# Altium schematic sheet power_supply.SchDoc
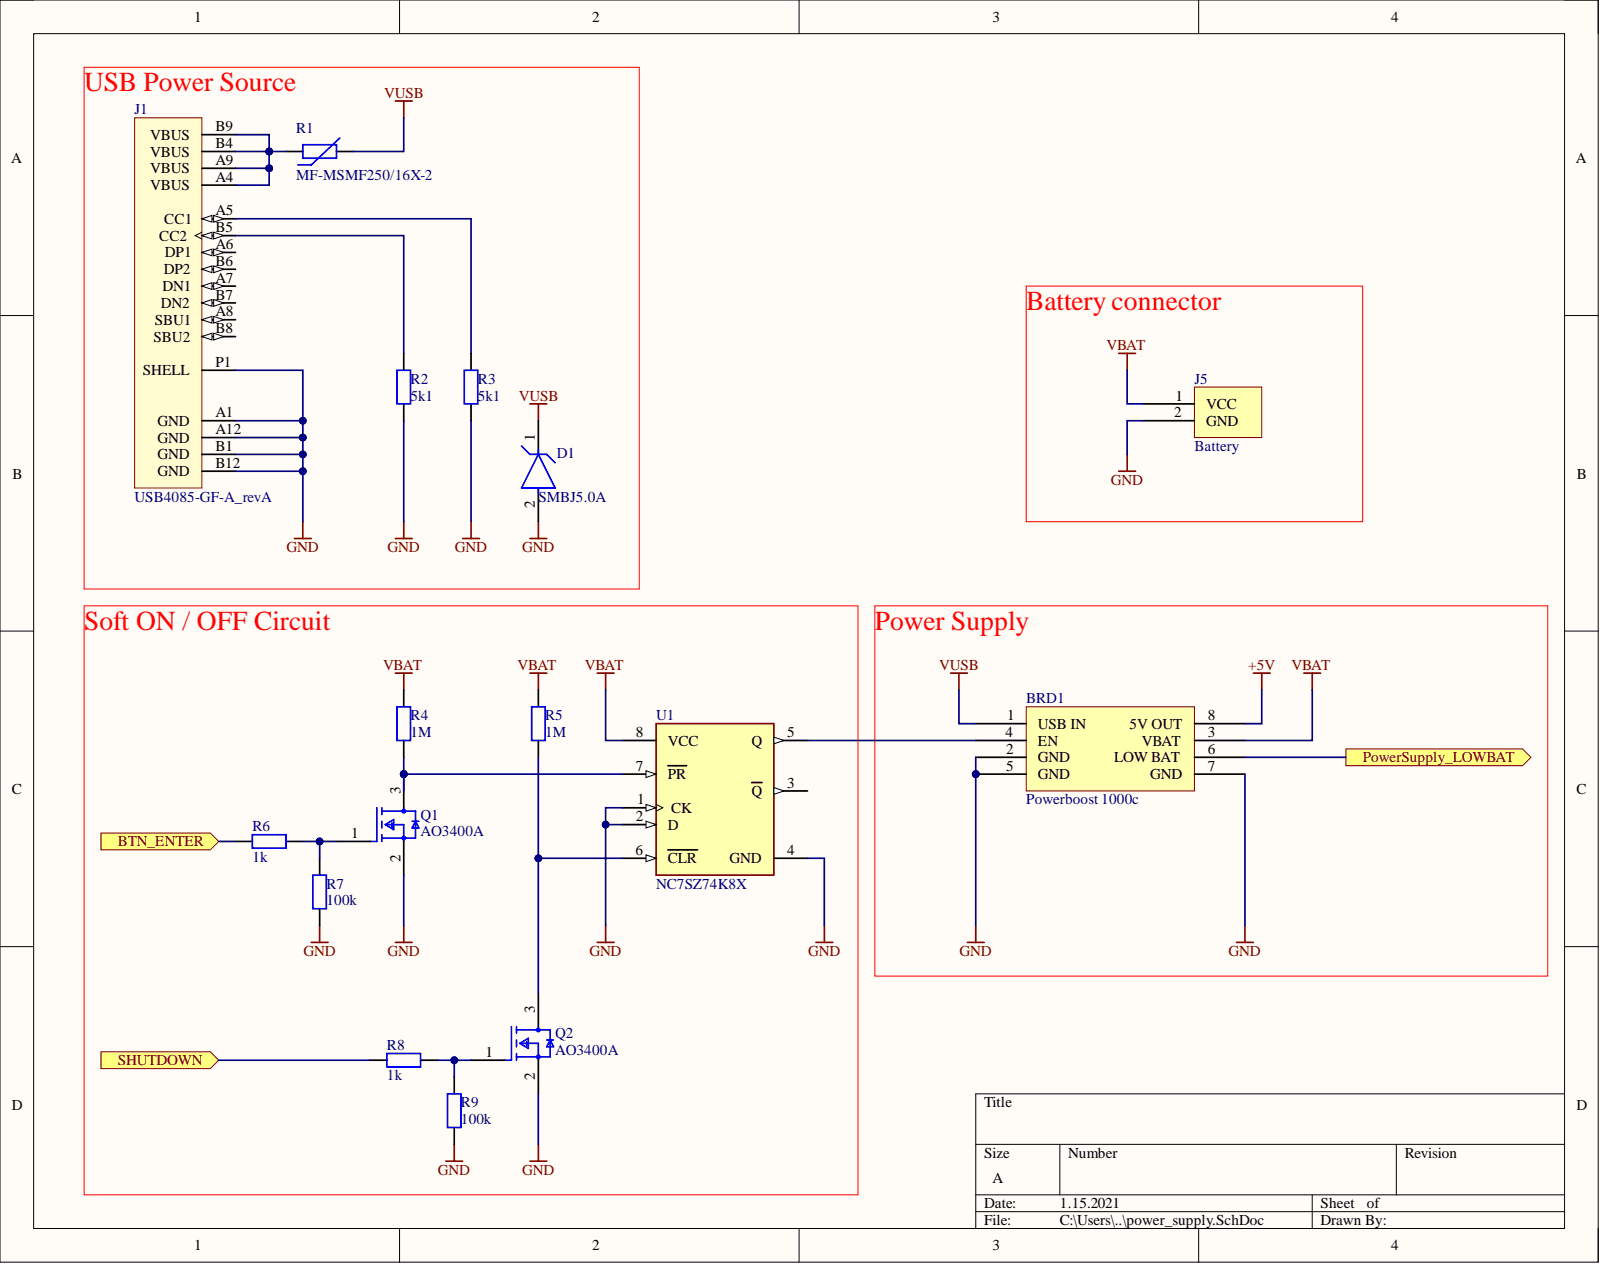
[diagram p0: power_supply.SchDoc]

## Parts
BRD1  Powerboost 1000c  [POWERBOOST_1000C]
D1  SMBJ5.0A  [FP-SMBJ-MFG]
J1  USB4085-GF-A_revA  [GCT_USB4085-GF-A_REVA]
J5  Battery  [FAN_CONNECTOR]
Q1  AO3400A  [SOT23]
Q2  AO3400A  [SOT23]
R1  MF-MSMF250/16X-2  [Res_1812]
R2  value 5k1  [RESC2013X50X30NL20T20]  (hidden comment: Res_0805)
R3  value 5k1  [RESC2013X50X30NL20T20]  (hidden comment: Res_0805)
R4  value 1M  [RESC2013X50X30NL20T20]  (hidden comment: Res_0805)
R5  value 1M  [RESC2013X50X30NL20T20]  (hidden comment: Res_0805)
R6  value 1k  [RESC2013X50X30NL20T20]  (hidden comment: Res_0805)
R7  value 100k  [RESC2013X50X30NL20T20]  (hidden comment: Res_0805)
R8  value 1k  [RESC2013X50X30NL20T20]  (hidden comment: Res_0805)
R9  value 100k  [RESC2013X50X30NL20T20]  (hidden comment: Res_0805)
U1  NC7SZ74K8X  [FP-846AN-MFG]

## Ports
BTN_ENTER, PowerSupply_LOWBAT, SHUTDOWN

## Nets
+5V: BRD1.8(5V_OUT)
GND: BRD1.2(GND) BRD1.5(GND) BRD1.7(GND) D1.2 J1.A1(GND) J1.A12(GND) J1.B1(GND) J1.B12(GND) J1.P1(SHELL) J5.2 Q1.2 Q2.2 R2.1 R3.1 R7.1 R9.1 U1.1(CK) U1.2(D) U1.4(GND)
VBAT: BRD1.3(VBAT) J5.1 R4.2 R5.2 U1.8(VCC)
VUSB: BRD1.1(USB_IN) D1.1 R1.2
BTN_ENTER: R6.1 ; port BTN_ENTER
PowerSupply_LOWBAT: BRD1.6(LOW_BAT) ; port PowerSupply_LOWBAT
SHUTDOWN: R8.1 ; port SHUTDOWN
NetBRD1_4: BRD1.4(EN) U1.5(Q)
NetJ1_A4: J1.A4(VBUS) J1.A9(VBUS) J1.B4(VBUS) J1.B9(VBUS) R1.1
NetJ1_A5: J1.A5(CC1) R3.2
NetJ1_B5: J1.B5(CC2) R2.2
NetQ1_1: Q1.1 R6.2 R7.2
NetQ1_3: Q1.3 R4.1 U1.7(PR)
NetQ2_1: Q2.1 R8.2 R9.2
NetQ2_3: Q2.3 R5.1 U1.6(CLR)

Not connected: J1 A6(DP1) A7(DN1) A8(SBU1) B6(DP2) B7(DN2) B8(SBU2); U1 3(Q)

## Library data of the parts
BRD1 = Powerboost_1000c: BOARD PWRBOOST1000 5V LIPO USB; Manufacturer: Adafruit Industries; Manufacturer Part Number: 2465; Evaluation Kit: Yes; Output Current: 1A; Output Voltage: 5.2V; Switching Frequency: 700kHz; Category: Embedded Processors and Controllers; Component Kind: Standard; ComponentLink1Description: https://media.digikey.com/pdf/Data%20Sheets/Adafruit%20PDFs/2465_Web.pdf?src-supplier=Digi-Key; ComponentLink1URL: https://media.digikey.com/pdf/Data%20Sheets/Adafruit%20PDFs/2465_Web.pdf?src-supplier=Digi-Key; Library Name: Schlib.SchLib; Package Quantity: 1; Pricing 1: 1=19.95000 (USD); RoHS: No; Stock 1: 834; Supplier 1: Digi-Key; Supplier Part Number 1: 1528-1349-ND
D1 = CMP-08607-000042-1: TVS DIODE 5V 9.2V DO214AA; Manufacturer: Littelfuse Inc; Breakdown Voltage: 6.4V; Direction: Unidirectional; Max Junction Temp: +150°C; Number Of Channels: 1; Peak Pulse Current: 65.3A; Peak Pulse Power: 600W; Automotive: No; Category: Diode; Clamping Voltage: 9.2V; Component Kind: Standard; ComponentLink2Description: Datasheet; ComponentLink2URL: https://www.littelfuse.com/~/media/electronics/datasheets/tvs_diodes/littelfuse_tvs_diode_smbj_datasheet.pdf.pdf; Device Class L1: Circuit Protection; Device Class L2: TVS Diodes; Device Class L3: unset; Digikey Description: TVS DIODE 5V 9.2V DO214AA; Height: 2.61mm; Lead Free: Yes; Library Name: Altium Content Vault; Manufacturer Part Number: SMBJ5.0A; Mouser Description: TVSS; Diode; For Data/Comm; Industrial Equip; 65.3A; SMD; Solder Term; Clamp-V 9.2V; Octopart: https://octopart.com/smbj5.0a-littelfuse-8835; Package: SMBJ; Reverse Standoff Voltage: 5V; RoHS: TRUE; Temperature: -65°C to +150°C; Temperature Range High: +150°C; Temperature Range Low: -65°C
J1 = USB-C port: USB 2.0 Type C Receptacle Dip Type, PCB Top Mount; MANUFACTURER: Global Connector Technology; Component Kind: Standard; PARTREV: A; STANDARD: Manufacturer Recommendations; Library Name: smart_lamp.SchLib
J5 = FAN: Tiger Claw(TM) Socket Strip, Through-hole, Vertical, -55 to 105 degC, 2 mm Pitch, 4-Pin, Female, RoHS; Manufacturer: Samtec; PartNumber: MMS-104-01-T-SV; Pitch: 2 mm; Component Kind: Standard; ComponentLink1Description: Manufacturer URL; ComponentLink1URL: http://www.samtec.com; ComponentLink2Description: Datasheet; ComponentLink2URL: http://www.samtec.com/documents/webfiles/pdf/MMS.PDF; ComponentLink3Description: Package Specification; ComponentLink3URL: http://www.samtec.com/documents/webfiles/cpdf/MMS-1XX-01-XXX-XX-XXX-MKT1.pdf; DatasheetVersion: F-214; Library Name: Schlib.SchLib; Mounting Technology: Through-hole; Orientation: Vertical; PackageDescription: 2mm Through Hole Box Connector Strip Assembly; PackageReference: MMS-104-01-X-SV; PackageVersion: AO, 2/1993; RoHS: True; Temperature Range: -55 to 105 degC
Q1 Q2 = MOSFET_AO3400A: MOSFET N-CH 30V 5.7A SOT23; Gate to Source Voltage (Vgs): 12V; Input Capacitance: 630pF; Max Power Dissipation: 1.4W; Number of Elements: 1; Rds On Max: 26.5mR; Case/Package: SOT-23; Component Kind: Standard; Continuous Drain Current (ID): 5.7A; Drain to Source Voltage (Vdss): 30V; Lead Free: Lead Free; Library Name: Schlib.SchLib; Max Operating Temperature: 150°C; Min Operating Temperature: -55°C; Mount: Surface Mount; Number of Pins: 3; Packaging: Tape and Reel; Power Dissipation: 1.4W; Radiation Hardening: No
R1 = PTC Fuse: PTC Resettable Fuse 2.5A(hold) 5A(trip) 16V 100A 1.2W 5s 0.015Ohm SMD Solder Pad 1812 T/R; Manufacturer: Bourns; Approvals: UL,CSA,TUV; Number of Terminals/Pins: 2; Resistance: 100mR; Case Code (Imperial): 1812; Case Code (Metric): 4532; Case/Package: 1812; Category: Fuses; Component Kind: Standard; ComponentLink1Description: https://datasheet.ciiva.com/3020/mfmsmf-3020420.pdf?src-supplier=Avnet; ComponentLink1URL: https://datasheet.ciiva.com/3020/mfmsmf-3020420.pdf?src-supplier=Avnet; ComponentLink2Description: https://datasheet.ciiva.com/16206/mfmsmf-16206071.pdf?src-supplier=Onlinecomponents.com; ComponentLink2URL: https://datasheet.ciiva.com/16206/mfmsmf-16206071.pdf?src-supplier=Onlinecomponents.com; ComponentLink3Description: https://datasheet.ciiva.com/7434/mfmsmf-7434296.pdf?src-supplier=Digi-Key; ComponentLink3URL: https://datasheet.ciiva.com/7434/mfmsmf-7434296.pdf?src-supplier=Digi-Key; ComponentLink4Description: https://datasheet.ciiva.com/32430/mf-msmf-series-eng-tds-32430600.pdf?src-supplier=Distrelec; ComponentLink4URL: https://datasheet.ciiva.com/32430/mf-msmf-series-eng-tds-32430600.pdf?src-supplier=Distrelec; ComponentLink5Description: https://datasheet.ciiva.com/7434/mfmsmf-7434298.pdf?src-supplier=Digi-Key; ComponentLink5URL: https://datasheet.ciiva.com/7434/mfmsmf-7434298.pdf?src-supplier=Digi-Key; ComponentLink6Description: https://datasheet.ciiva.com/16692/mfmsmf-16692202.pdf?src-supplier=Avnet+Europe; ComponentLink6URL: https://datasheet.ciiva.com/16692/mfmsmf-16692202.pdf?src-supplier=Avnet+Europe; ComponentLink7Description: https://datasheet.ciiva.com/30088/575mfmsmf-30088346.pdf?src-supplier=Onlinecomponents.com; ComponentLink7URL: https://datasheet.ciiva.com/30088/575mfmsmf-30088346.pdf?src-supplier=Onlinecomponents.com; ComponentLink8Description: https://datasheet.ciiva.com/7434/mfmsmf-7434299.pdf?src-supplier=Digi-Key; ComponentLink8URL: https://datasheet.ciiva.com/7434/mfmsmf-7434299.pdf?src-supplier=Digi-Key; ComponentLink9Description: https://datasheet.ciiva.com/14538/70153434-14538955.pdf?src-supplier=Allied+Electronics; ComponentLink9URL: https://datasheet.ciiva.com/14538/70153434-14538955.pdf?src-supplier=Allied+Electronics; ComponentLink10Description: https://datasheet.ciiva.com/8005/1786007-8005325.pdf?src-supplier=Element14; ComponentLink10URL: https://datasheet.ciiva.com/8005/1786007-8005325.pdf?src-supplier=Element14; ComponentLink11Description: https://datasheet.ciiva.com/48700/001055646-da-01-en-sich-ptc-reset-mf-msmf250-16x-2-2-5a16v-48700197.pdf?src-supplier=Conrad; ComponentLink11URL: https://datasheet.ciiva.com/48700/001055646-da-01-en-sich-ptc-reset-mf-msmf250-16x-2-2-5a16v-48700197.pdf?src-supplier=Conrad; Contact Plating: Gold; Current Rating: 100A; Height: 1.6mm; Hold Current: 2.5A; Length: 4.73mm; Manufacturer Part Number: MF-MSMF250/16X-2; Max Current Rating: 100A; Max Operating Temperature: 85°C; Min Operating Temperature: -40°C; Mount: Surface Mount,PCB; Package Quantity: 1500; Packaging: Tape and Reel; Power Dissipation: 1.2W; Pricing 1: 1=0.54000, 5=0.52000, 10=0.50400, 50=0.42400, 100=0.35200, 250=0.32000, 500=0.27200 (USD); Radiation Hardening: No; REACH SVHC: No SVHC; RoHS: Yes; Stock 1: 33109; Supplier 1: Digi-Key; Supplier Part Number 1: MF-MSMF250/16X-2CT-ND; Termination: SMD/SMT; Time to Trip: 5s; Trip Current: 5A; Voltage Rating: 16V; Voltage Rating (DC): 16V; Width: 3.41mm; Library Name: smart_lamp.SchLib
R2-R9 = Res_0805: Resistor; Power Rating: 125mW; Tolerance: 1%; Component Kind: Standard; Signal Integrity: Res; Simulation: RESISTOR; Library Name: Biral_Generic.IntLib
U1 = CMP-07113-000002-1: IC FF D-TYPE SNGL 1BIT US8; Manufacturer: ON Semiconductor; Frequency: 250MHz; Logic Function Desc: Non-inverting; Max Junction Temp: +150°C; Max Supply Voltage: 5.5V; Min Supply Voltage: 1.65V; Number Of Circuits: 1; Number Of Outputs: 2; Propagation Delay: 2.6ns; Quiescent Current: 1uA; Standoff Height: 0mm; Ambient Temperature Range High: +85°C; Ambient Temperature Range Low: -40°C; Automotive: No; Category: IC; Component Kind: Standard; ComponentLink2Description: Datasheet; ComponentLink2URL: https://www.onsemi.com/pub/Collateral/NC7SZ74-D.PDF; Device Class L1: Integrated Circuits (ICs); Device Class L2: Logic ICs; Device Class L3: Latches and Flip Flops; Digikey Description: IC FF D-TYPE SNGL 1BIT US8; Footprint Url: https://www.onsemi.com/pub/Collateral/846AN.PDF; Height: 0.9mm; Ipc Land Pattern Name: SOP50P200X90-8; Lead Free: Yes; Library Name: Altium Content Vault; Manufacturer Part Number: NC7SZ74K8X; Mouser Description: Flip Flops TinyLogic UHS D-Type; Number Of Inputs: 1; Octopart: https://octopart.com/nc7sz74k8x-on+semiconductor-84330810; Package: 846AN; RoHS: TRUE; Temperature: to +150°C; Temperature Range High: +150°C
